# Altium schematic sheet DVT-PSU-LM5116-HT_2.SchDoc(DVT-PSU-LM5116-HT_2)
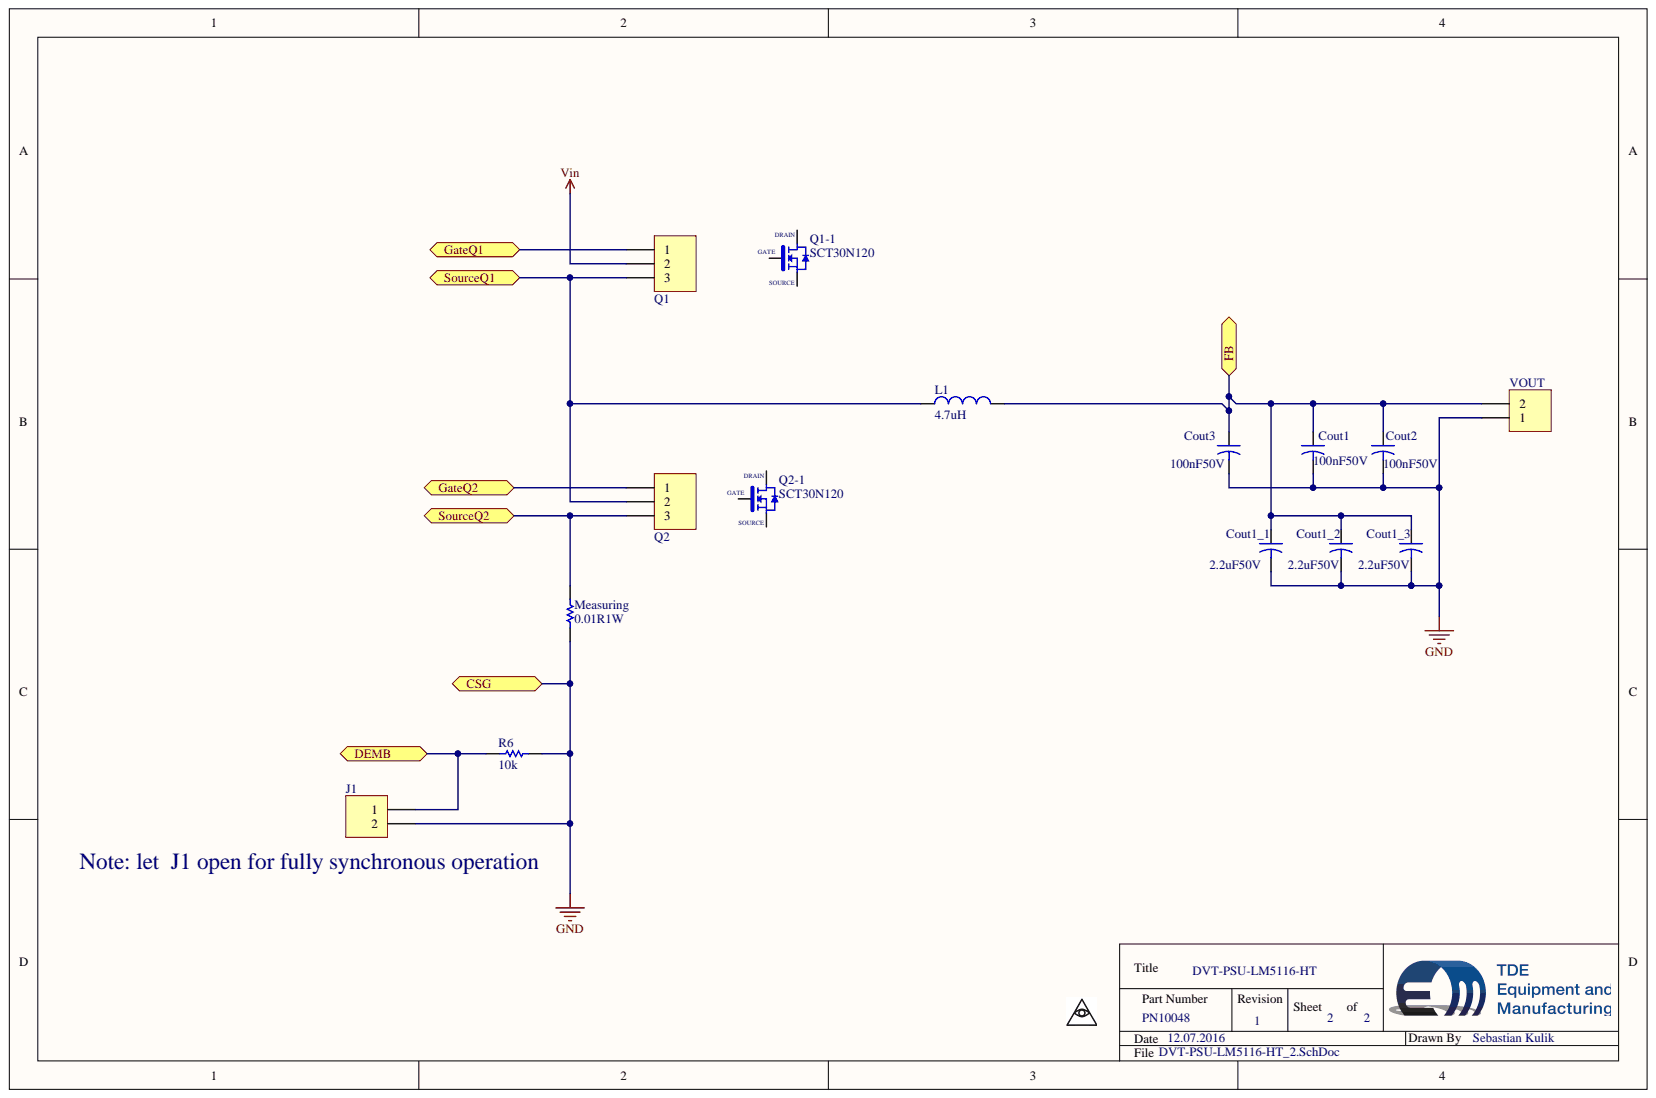
[diagram p0: DVT-PSU-LM5116-HT_2.SchDoc]

## Parts
**  Eye  [Eye]  (value not drawn)
Cout1  value 100nF50V  [Dummy-10mm]  (hidden comment: Capacitor-Dummy-THT)
Cout1_1  value 2.2uF50V  [Dummy-10mm]  (hidden comment: Capacitor-Dummy-THT)
Cout1_2  value 2.2uF50V  [Dummy-10mm]  (hidden comment: Capacitor-Dummy-THT)
Cout1_3  value 2.2uF50V  [Dummy-10mm]  (hidden comment: Capacitor-Dummy-THT)
Cout2  value 100nF50V  [Dummy-10mm]  (hidden comment: Capacitor-Dummy-THT)
Cout3  value 100nF50V  [Dummy-10mm]  (hidden comment: Capacitor-Dummy-THT)
J1  Plug_2_90_2.54  [HDR1X2]  (value not drawn)
L1  value 4.7uH  [WE-LHMI1335]  (hidden comment: 4u7H7.5A)
Measuring  value 0.01R1W  [Dummy-10mm]  (hidden comment: Resistor-Dummy-THT)
Q1  3Pol_TBL_5mm  [WE-TBL3-5]  (value not drawn)
Q2  3Pol_TBL_5mm  [WE-TBL3-5]  (value not drawn)
R6  value 10k  [Dummy-10mm]  (hidden comment: Resistor-Dummy-THT)
VOUT  PHOENIX_1715721  [Phoenix-2-5_08]  (value not drawn)

## Ports
CSG, DEMB, FB, GATEQ1, GATEQ2, SOURCEQ1, SOURCEQ2

## Nets
VIN: Q1.2
DEMB: J1.1 R6.1 ; port DEMB
FB: Cout1.1 Cout1_1.1 Cout1_2.1 Cout1_3.1 Cout2.1 Cout3.1 L1.2 VOUT.2 ; port FB
GND: Cout1.2 Cout1_1.2 Cout1_2.2 Cout1_3.2 Cout2.2 Cout3.2 J1.2 Measuring.1 R6.2 VOUT.1 ; port CSG
NetL1_1: L1.1 Q1.3 Q2.2 ; port SOURCEQ1
NetMeasuring_2: Measuring.2 Q2.3 ; port SOURCEQ2
NetQ1_1: Q1.1 ; port GATEQ1
NetQ2_1: Q2.1 ; port GATEQ2

## Library data of the parts
Cout1-Cout3 = Capacitor-Dummy-THT: Deliverer PartNr: 2452193; Deliverer: Farnell; Component Kind: Standard; Description: Capacitor-Dummy-THT; Library Name: Capacitors.IntLib; Manufacturer: -; Manufacturer PartNr: -; PackageDescription: -; Part Description: Capacitor-Dummy-THT; Price: 10.64/1; Published: 08.12.2015; Signal Integrity: Cap; Simulation: CAP; TDE-PN: -; Publisher: Sebastian Kulik
Cout1_1-Cout1_3 = Capacitor-Dummy-THT: Deliverer PartNr: 2529490; Deliverer: Farnell; Component Kind: Standard; Description: Capacitor-Dummy-THT; Library Name: Capacitors.IntLib; Manufacturer: -; Manufacturer PartNr: -; PackageDescription: -; Part Description: Capacitor-Dummy-THT; Price: 1.28/1; Published: 08.12.2015; Signal Integrity: Cap; Simulation: CAP; TDE-PN: -; Publisher: Sebastian Kulik
J1 = Plug_2_90_2.54: Plug, 2-Pin; Deliverer PartNr: 2356176; Manufacturer: WE; Manufacturer PartNr: 61300211021; Deliverer: Farnell; TDE-PN: CON0010; Component Kind: Standard; LatestRevisionDate: 14.05.2014; LatestRevisionNote: Re-released for DXP Platform.; Library Name: Connectors.IntLib; Signal Integrity: Connector; Publisher: Sebastian Kulik
L1 = 4u7H7.5A: WE, LHMI; Deliverer PartNr: 74437377047; TDE-PN: P0041; Component Kind: Standard; Deliverer: WE; Library Name: Passives.IntLib; Manufacturer: WE; Manufacturer PartNr: 74437377047; PackageDescription: LHM1335; Price: 2.48/1; Published: 11.07.2016; Publisher: Sebastian Kulik
Measuring = Resistor-Dummy-THT: LVR01, 0.01 ohm, 1 W, ± 1%; Deliverer PartNr: 1108056; Manufacturer: Vishay; Manufacturer PartNr: LVR01R0100FE70; Deliverer: Farnell; Component Kind: Standard; Library Name: Resistors.IntLib; PackageDescription: -; Part Desicription: Resistor-Dummy-THT; Price: 2.61/1; Published: 08.12.2015; Signal Integrity: Res; Simulation: RESISTOR; TDE-PN: -; Publisher: Sebastian Kulik
Q1 Q2 = 3Pol_TBL_5mm: Plug,-3-Pin; Deliverer PartNr: 826-7220; Manufacturer: WE; Manufacturer PartNr: 691102710003; Deliverer: RS; TDE-PN: CON0044; Component Kind: Standard; Library Name: Connectors.IntLib; Price: 1.26/1; Publisher: Sebastian Kulik
R6 = Resistor-Dummy-THT: Deliverer PartNr: 148-736; Deliverer: RS; Component Kind: Standard; Description: Resistor-Dummy-THT; Library Name: Resistors.IntLib; Manufacturer: -; Manufacturer PartNr: -; PackageDescription: -; Part Desicription: Resistor-Dummy-THT; Price: 0.027/10; Published: 08.12.2015; Signal Integrity: Res; Simulation: RESISTOR; TDE-PN: -; Publisher: Sebastian Kulik
VOUT = 2Pol_1715721: Phoenix, 2 pol, 5.08mm, 22A, 715721; Deliverer PartNr: 193-0564; Deliverer: RS; TDE-PN: CON0008; Component Kind: Standard; LatestRevisionDate: 02.05.2014; LatestRevisionNote: Re-released for DXP Platform.; Library Name: Connectors.IntLib; Manufacturer: Phoenix; Manufacturer PartNr: 1715721; PackageDescription: Phoenix-2-5_08; Price: 0.976/5; Signal Integrity: Connector; Publisher: Sebastian Kulik
** = Eye: Component Kind: Standard; Library Name: ICs.IntLib
